# Altium schematic sheet FuelGauge_Sheet.SchDoc
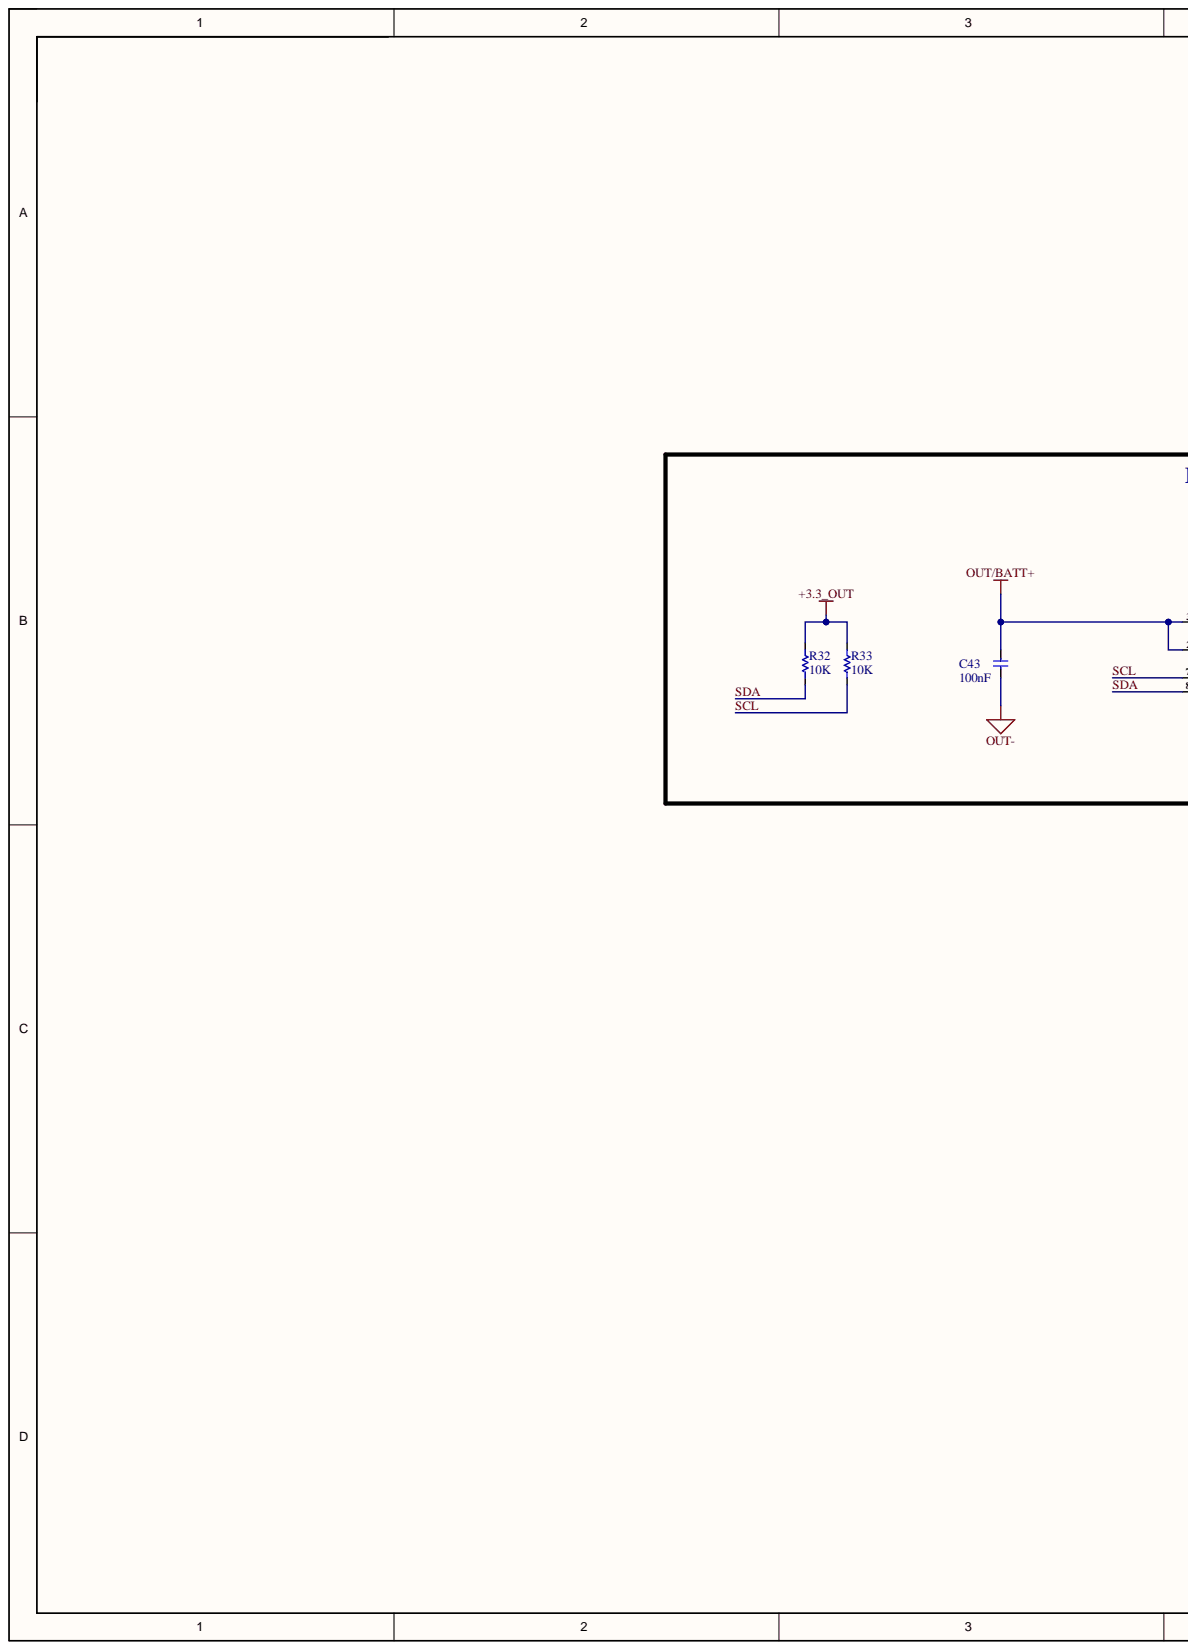
[diagram p0: FuelGauge_Sheet.SchDoc, left]
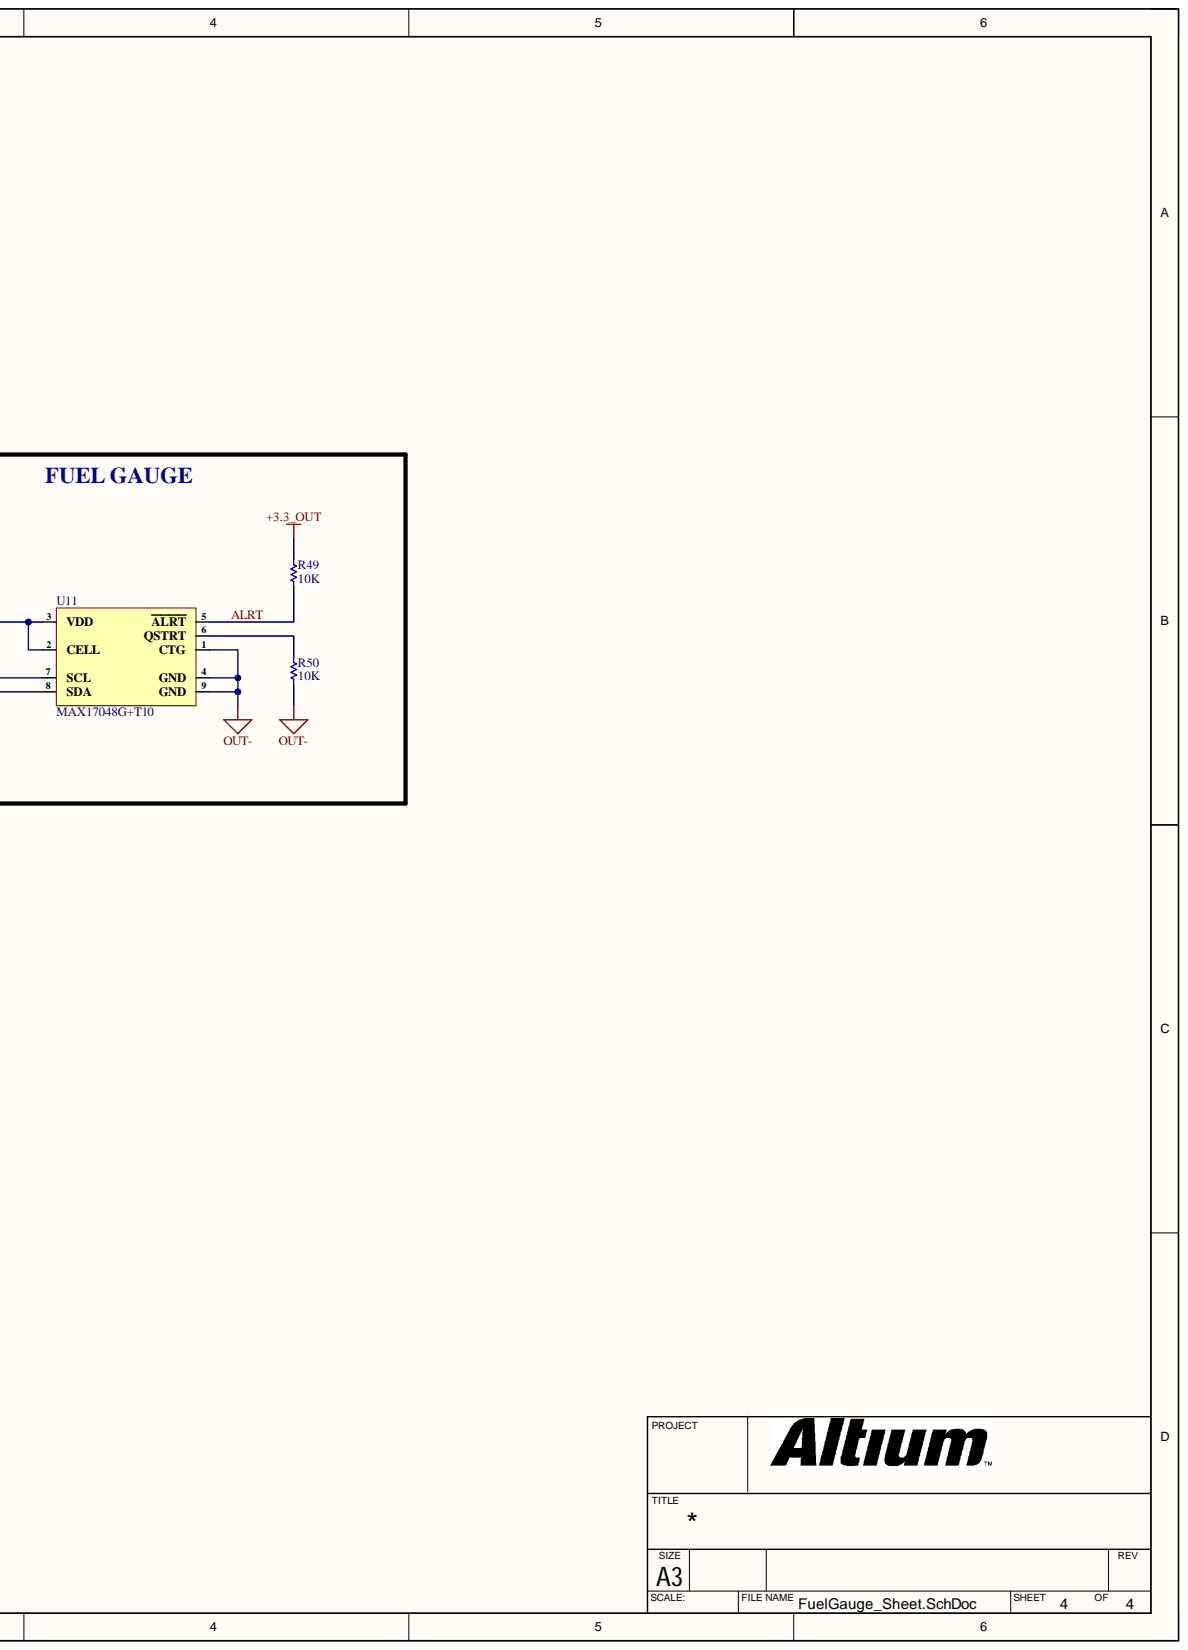
[diagram p1: FuelGauge_Sheet.SchDoc, right]

## Parts
C43  100nF  [cap-0402]
R32  10K  [Res-0402]
R33  10K  [Res-0402]
R49  10K  [Res-0402]
R50  10K  [Res-0402]
U11  MAX17048G+T10  [8-TDFN-EP (2x2)]

## Nets
+3.3_OUT: R32.2 R33.2 R49.2
OUT-: C43.2 R50.1 U11.1(CTG) U11.4(GND) U11.9(GND)
OUT/BATT+: C43.1 U11.2(CELL) U11.3(VDD)
ALRT: R49.1 U11.5(ALRT)
SCL: R33.1 U11.7(SCL)
SDA: R32.1 U11.8(SDA)
NetR50_2: R50.2 U11.6(QSTRT)

## Library data of the parts
C43 = C1005X7R1H104KT000F: CAP CER 0.1UF 50V X7R 0402; Manufacturer: TDK; Item Type: Capacitor; Tolerance: 10%; Voltage: 25V; Category: Ceramic Capacitors; Component Kind: Standard; ComponentLink1Description: LCSC; ComponentLink1URL: https://www.lcsc.com/product-detail/Multilayer-Ceramic-Capacitors-MLCC-SMD-SMT_TDK-C1005X7R1H104KT000F_C361198.html; Dielectric: X7R; LCSC Part Number: C361198; Library Name: eSpark Libraries.IntLib; Manufacturer Part Number: C1005X7R1H104KT000F; RoHS: No; Supplier 1: Avnet; Supplier Part Number 1: C1005X7R1H104KT000F
R32 R33 R49 R50 = RC0402FR-0710KL: RES 10K OHM 1% 1/16W 0402; Manufacturer: Yageo; Item Type: Resistor; Max Power Dissipation: 63mW; Power Rating: 62.5mW; Resistance: 10kR; Temperature Coefficient: 100ppm/°C; Voltage Rating: 50V; Case Code (Imperial): 0402; Case Code (Metric): 1005; Case/Package: 0402; Category: Chip SMD Resistors; Component Kind: Standard; ComponentLink1Description: https://datasheet.ciiva.com/7660/38568-7660787.pdf?src-supplier=Element14; ComponentLink1URL: https://datasheet.ciiva.com/7660/38568-7660787.pdf?src-supplier=Element14; ComponentLink2Description: https://datasheet.ciiva.com/7849/1686128-7849814.pdf?src-supplier=Element14; ComponentLink2URL: https://datasheet.ciiva.com/7849/1686128-7849814.pdf?src-supplier=Element14; ComponentLink3Description: https://datasheet.ciiva.com/7983/1018594-7983486.pdf?src-supplier=Element14; ComponentLink3URL: https://datasheet.ciiva.com/7983/1018594-7983486.pdf?src-supplier=Element14; ComponentLink4Description: https://datasheet.ciiva.com/22747/1018594-22747512.pdf?src-supplier=Element14; ComponentLink4URL: https://datasheet.ciiva.com/22747/1018594-22747512.pdf?src-supplier=Element14; ComponentLink5Description: https://datasheet.ciiva.com/12667/pyu-rc0402-51-rohs-l-6-r-12667067.pdf?src-supplier=Mouser; ComponentLink5URL: https://datasheet.ciiva.com/12667/pyu-rc0402-51-rohs-l-6-r-12667067.pdf?src-supplier=Mouser; ComponentLink6Description: https://datasheet.ciiva.com/7849/1784430-7849813.pdf?src-supplier=Element14; ComponentLink6URL: https://datasheet.ciiva.com/7849/1784430-7849813.pdf?src-supplier=Element14; ComponentLink7Description: https://datasheet.ciiva.com/7849/1784430-7849815.pdf?src-supplier=Element14; ComponentLink7URL: https://datasheet.ciiva.com/7849/1784430-7849815.pdf?src-supplier=Element14; Construction: Rectangular; Depth: 0.5mm; Features: Moisture Resistant; Height: 0.32mm; LCSC Part Number: C60490; Lead Free: Lead Free; Lead Pitch: 0.2mm; Length: 1mm; Library Name: eSpark Libraries.IntLib; Manufacturer Part Number: RC0402FR-0710KL; Max Operating Temperature: 155°C; Military Standard: Not; Min Operating Temperature: -55°C; Number of Pins: 2; Number of Terminations: 2; Packaging: Tape and Reel; Pricing 1: 1=0.10000, 10=0.01400, 50=0.01400, 100=0.00500, 1000=0.00300, 10000=0.00200 (USD); REACH SVHC: No SVHC; Resistance Tolerance: 1%; Resistor Type: Thick Film; RoHS: Yes; RoHS Compliant: Yes; Series: RC; Stock 1: 18648329; Supplier 1: Mouser; Supplier Part Number 1: 603-RC0402FR-0710KL; Termination: SMD/SMT; Tolerance: 1%; Voltage Rating (DC): 50V; Width: 0.5mm; Working Voltage: 50V
U11 = MAX17048G+T10: IC BATT MON LI-ION 1CELL 8TDFN; Manufacturer: Maxim; Operating Supply Current: 23uA; Operating Supply Voltage: 4.5V; Output Voltage: 400mV; Category: Battery Management; Component Kind: Standard; ComponentLink1Description: https://datasheet.ciiva.com/14083/max17048-max17049-14083973.pdf?src-supplier=Digi-Key; ComponentLink1URL: https://datasheet.ciiva.com/14083/max17048-max17049-14083973.pdf?src-supplier=Digi-Key; Contact Plating: Tin,Matte; Item Type: IC; LCSC Part Number: C2682616; Library Name: eSpark Libraries.IntLib; Manufacturer Part Number: MAX17048G+T10; Max Operating Temperature: 85°C; Min Operating Temperature: -20°C; Mount: Surface Mount; Number of Pins: 8; Package Quantity: 10000; Packaging: Tape and Reel; Pricing 1: 1=3.34000, 10=3.00000, 50=2.84000, 100=2.46000, 1000=1.89000, 10000=1.83000 (USD); Radiation Hardening: No; RoHS: Yes; RoHS Compliant: Yes; Series: ModelGauge™; Stock 1: 41293; Supplier 1: Mouser; Supplier Part Number 1: 700-MAX17048G+T10
